# Altium schematic sheet 飞控.SchDoc
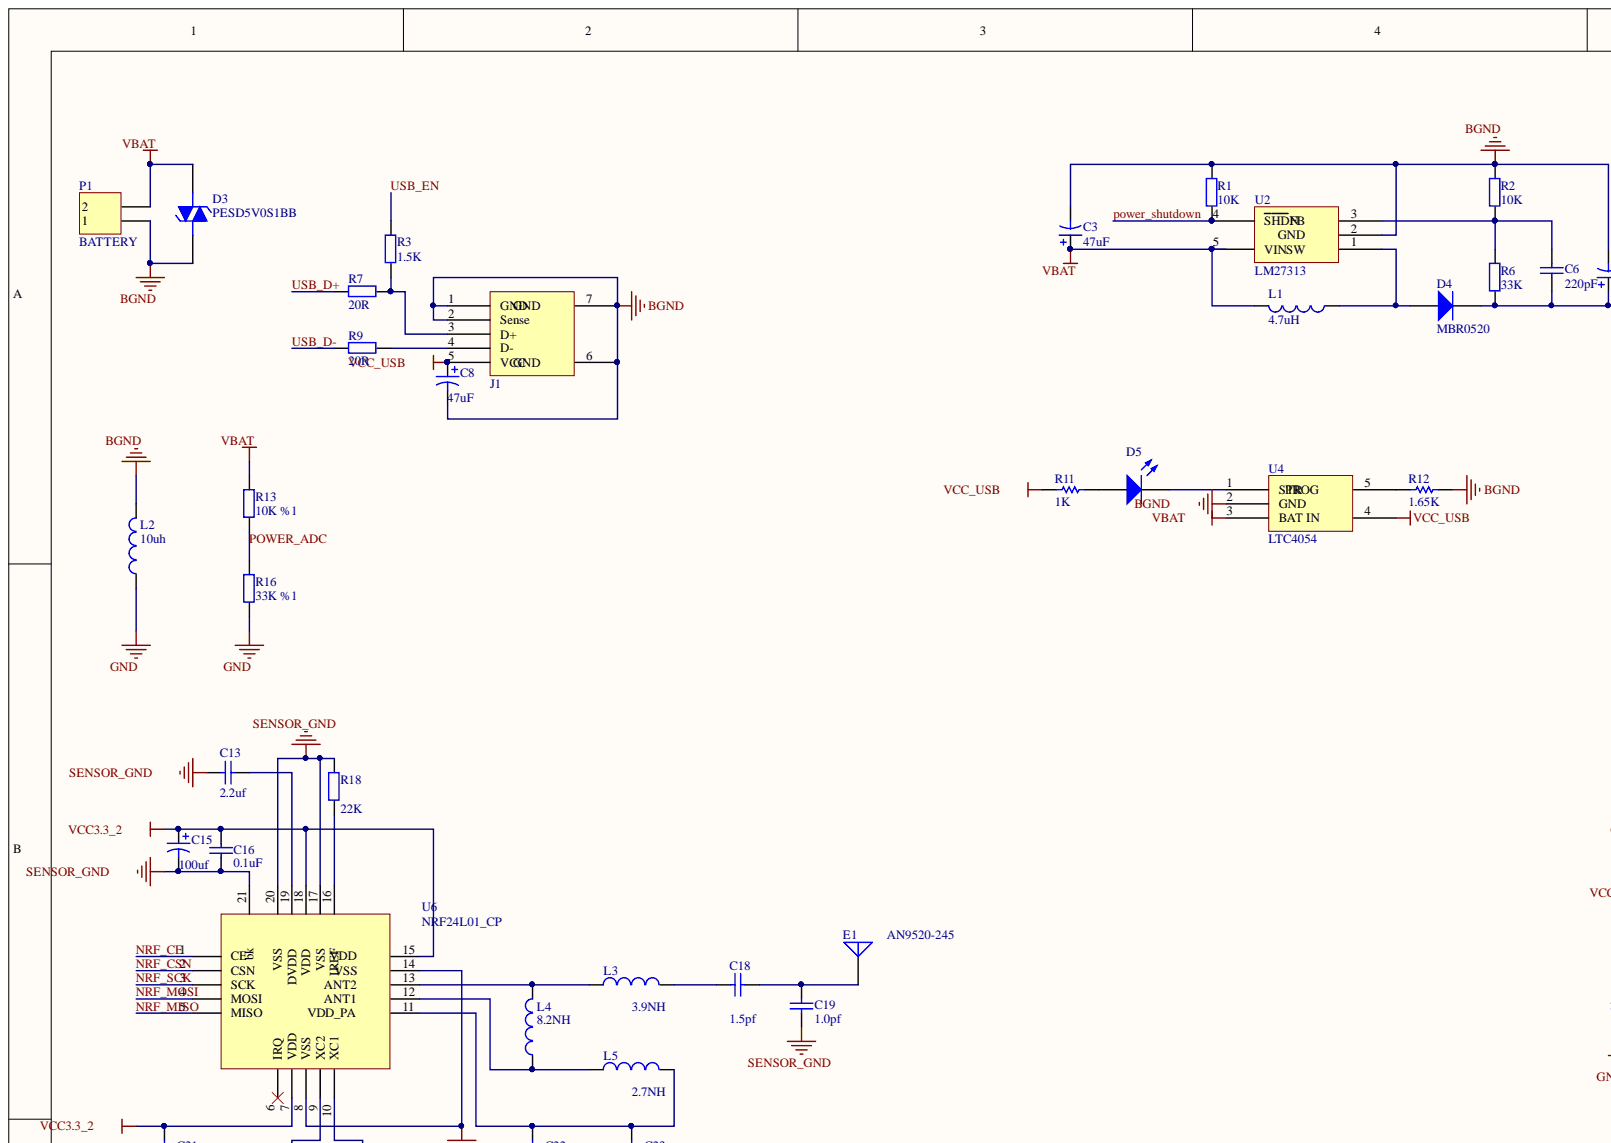
[diagram p0: 飞控.SchDoc, top left]
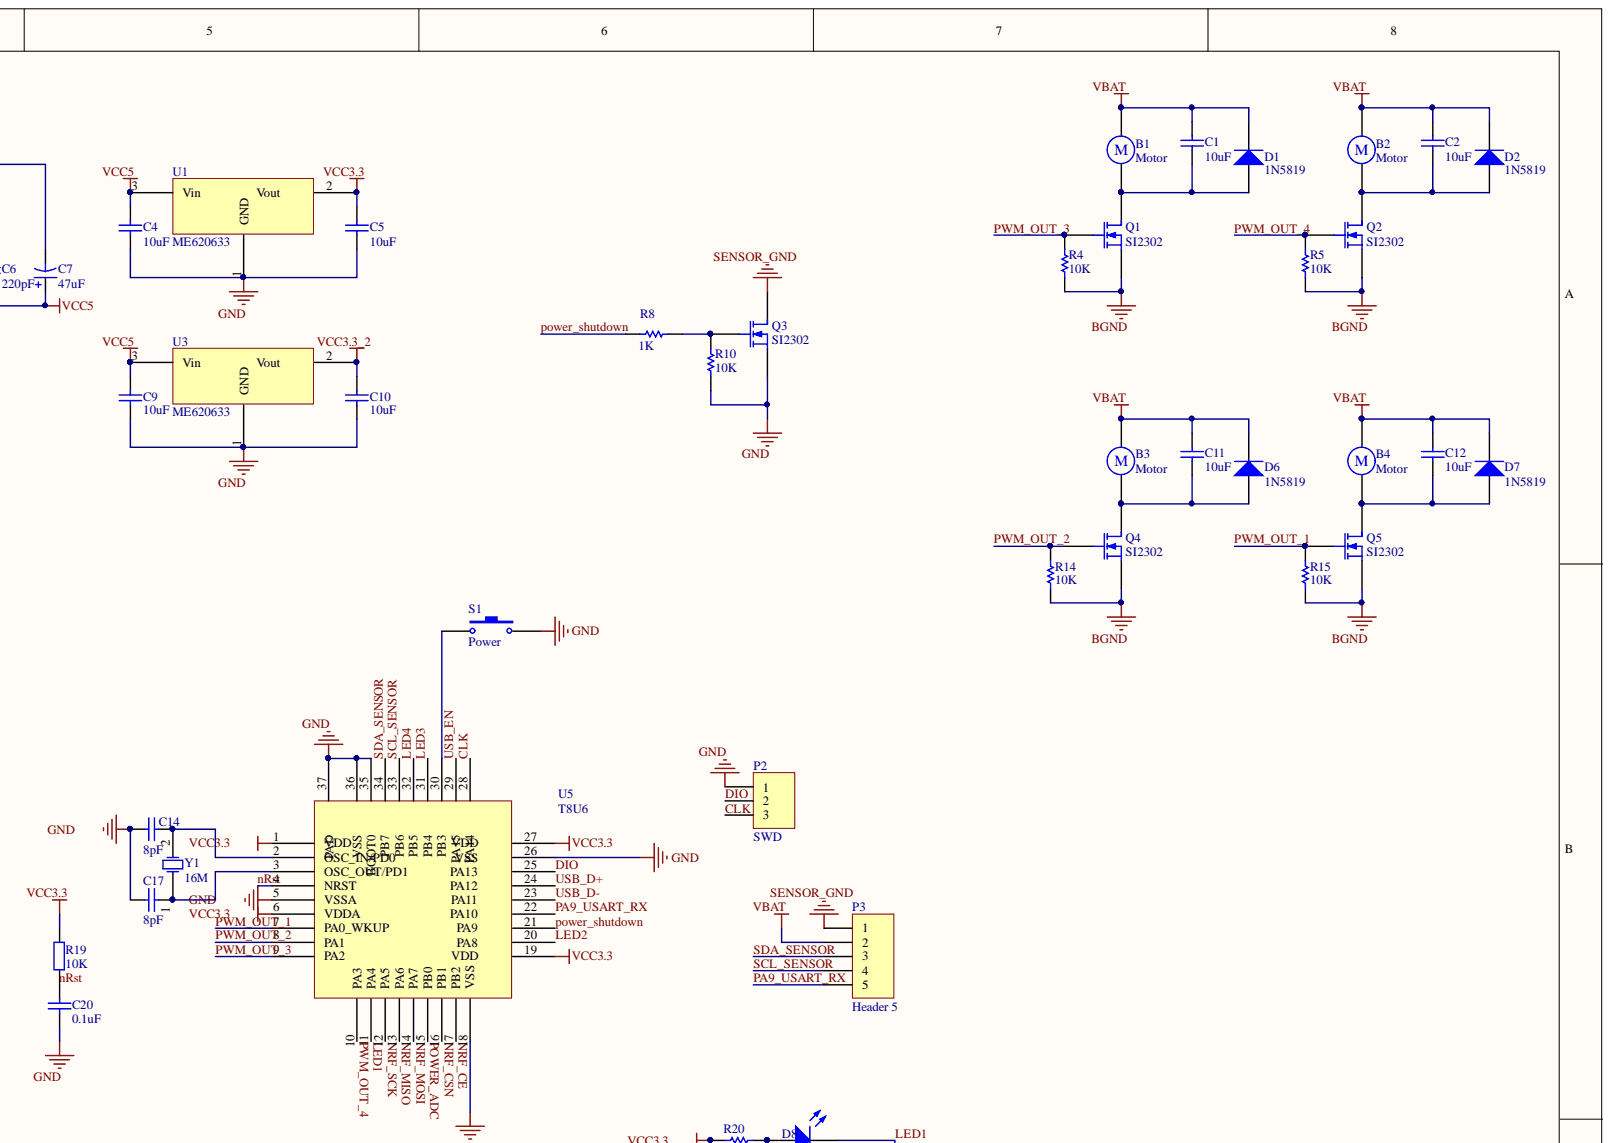
[diagram p1: 飞控.SchDoc, top right]
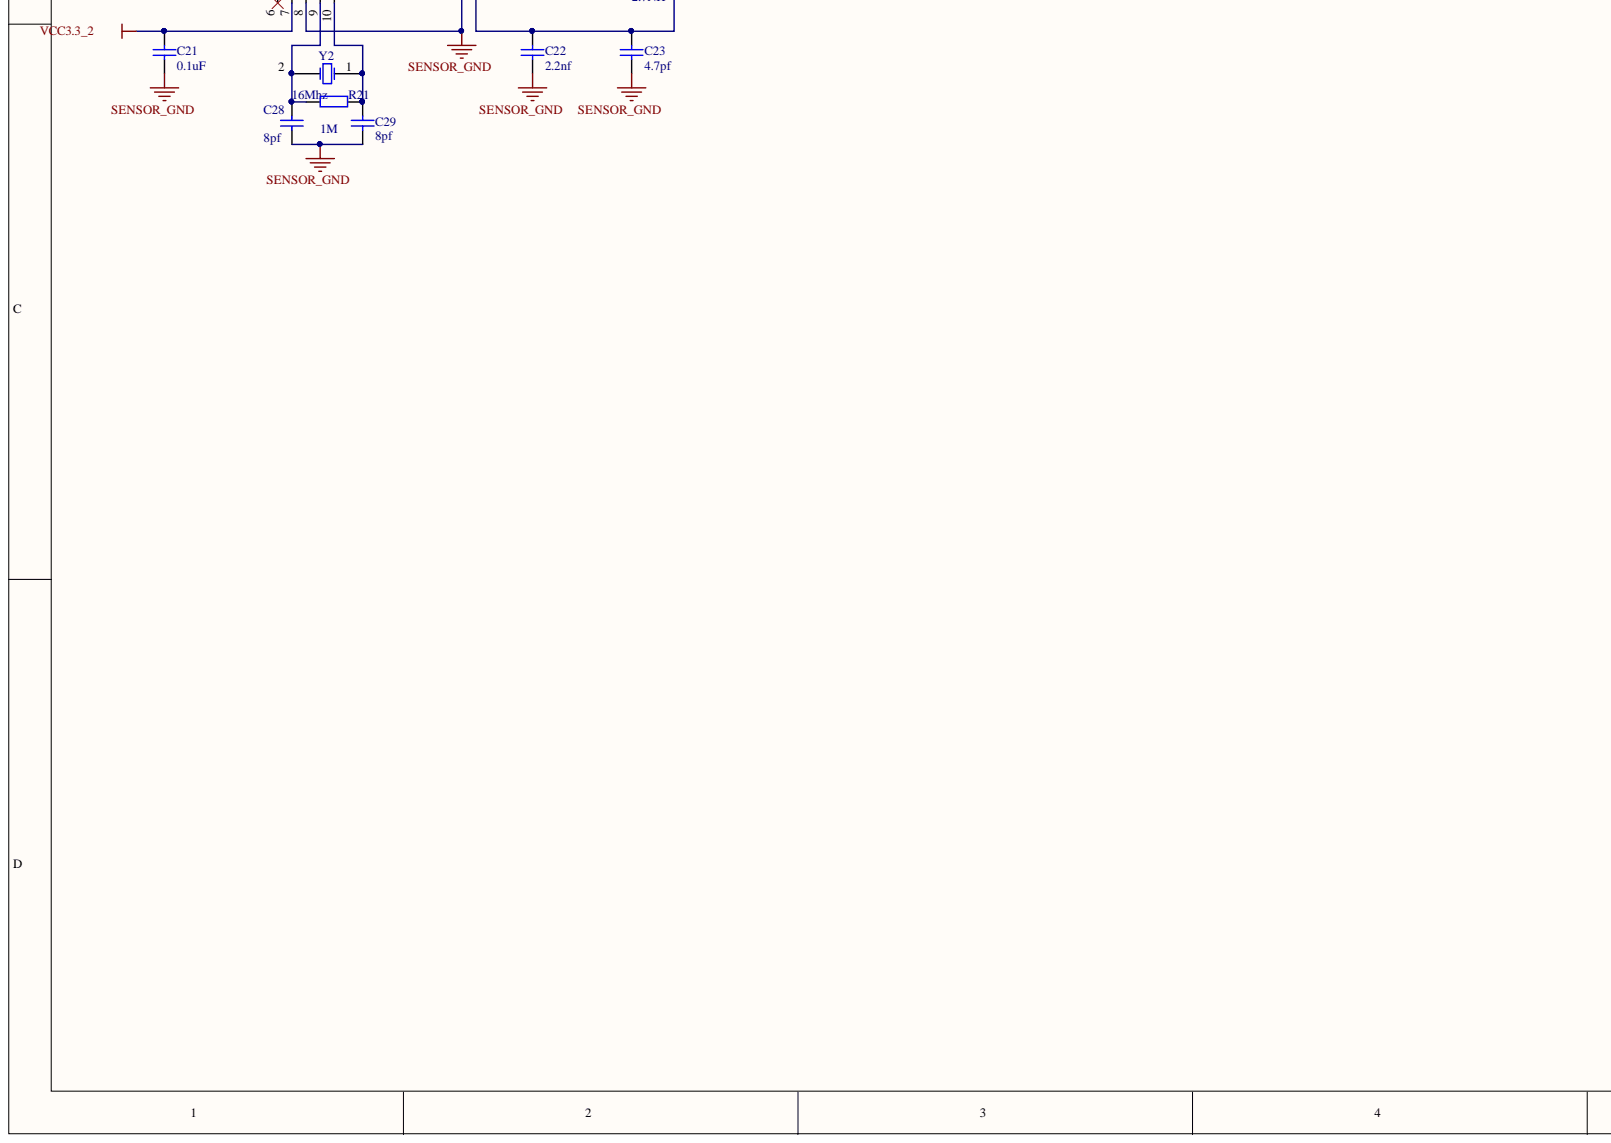
[diagram p2: 飞控.SchDoc, bottom left]
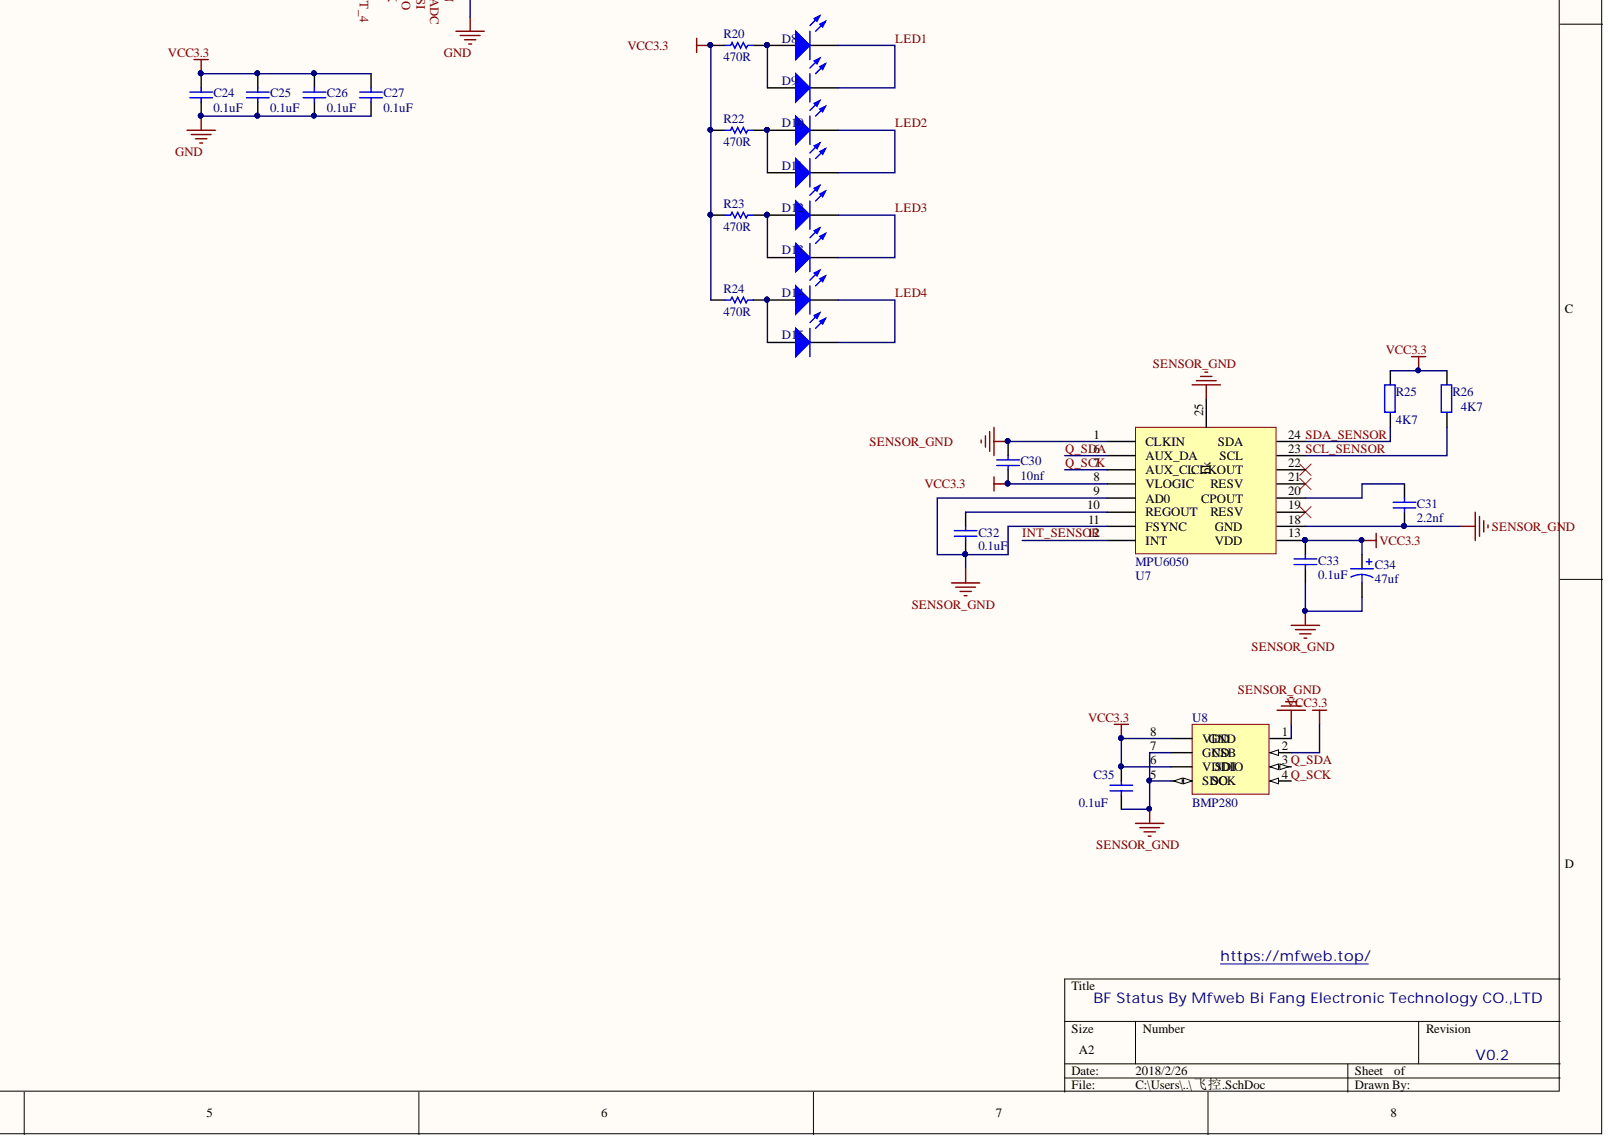
[diagram p3: 飞控.SchDoc, bottom right]

## Parts
B1  Motor  [2.54连接器]
B2  Motor  [2.54连接器]
B3  Motor  [2.54连接器]
B4  Motor  [2.54连接器]
C1  value 10uF  [0402]  (hidden comment: Cap)
C2  value 10uF  [0402]  (hidden comment: Cap)
C3  value 47uF  [0805]  (hidden comment: Cap Pol1)
C4  value 10uF  [0402]  (hidden comment: Cap)
C5  value 10uF  [0402]  (hidden comment: Cap)
C6  value 220pF  [0402]  (hidden comment: Cap)
C7  value 47uF  [0805D]  (hidden comment: Cap Pol1)
C8  value 47uF  [0805D]  (hidden comment: Cap Pol1)
C9  value 10uF  [0402]  (hidden comment: Cap)
C10  value 10uF  [0402]  (hidden comment: Cap)
C11  value 10uF  [0402]  (hidden comment: Cap)
C12  value 10uF  [0402]  (hidden comment: Cap)
C13  value 2.2uf  [0402]  (hidden comment: Cap)
C14  value 8pF  [0402]  (hidden comment: Cap)
C15  value 100uf  [0805D]  (hidden comment: Cap Pol1)
C16  value 0.1uF  [0402]  (hidden comment: Cap)
C17  value 8pF  [0402]  (hidden comment: Cap)
C18  value 1.5pf  [0402]  (hidden comment: Cap)
C19  value 1.0pf  [0402]  (hidden comment: Cap)
C20  value 0.1uF  [0402]  (hidden comment: Cap)
C21  value 0.1uF  [0402]  (hidden comment: Cap)
C22  value 2.2nf  [0402]  (hidden comment: Cap)
C23  value 4.7pf  [0402]  (hidden comment: Cap)
C24  value 0.1uF  [0402]  (hidden comment: Cap)
C25  value 0.1uF  [0402]  (hidden comment: Cap)
C26  value 0.1uF  [0402]  (hidden comment: Cap)
C27  value 0.1uF  [0402]  (hidden comment: Cap)
C28  value 8pf  [0402]  (hidden comment: Cap)
C29  value 8pf  [0402]  (hidden comment: Cap)
C30  value 10nf  [0402]  (hidden comment: Cap)
C31  value 2.2nf  [0402]  (hidden comment: Cap)
C32  value 0.1uF  [0402]  (hidden comment: Cap)
C33  value 0.1uF  [0402]  (hidden comment: Cap)
C34  value 47uf  [0603]  (hidden comment: Cap Pol1)
C35  value 0.1uF  [0402]  (hidden comment: Cap)
D1  1N5819  [0805P]
D2  1N5819  [0805P]
D3  PESD5V0S1BB  [0603]
D4  MBR0520  [SOD-123]
D5  LED0  [0805P]  (value not drawn)
D6  1N5819  [0805P]
D7  1N5819  [0805P]
D8  LED0  [0805P]  (value not drawn)
D9  LED0  [0805P]  (value not drawn)
D10  LED0  [0805P]  (value not drawn)
D11  LED0  [0805P]  (value not drawn)
D12  LED0  [0805P]  (value not drawn)
D13  LED0  [0805P]  (value not drawn)
D14  LED0  [0805P]  (value not drawn)
D15  LED0  [0805P]  (value not drawn)
E1  AN9520-245  [AN9520-245]
J1  MicroUsb  [Micro_USB]  (value not drawn)
L1  value 4.7uH  [CD32]  (hidden comment: Inductor)
L2  value 10uh  [CD32]  (hidden comment: Inductor)
L3  value 3.9NH  [0402]  (hidden comment: Inductor)
L4  value 8.2NH  [0402]  (hidden comment: Inductor)
L5  value 2.7NH  [0402]  (hidden comment: Inductor)
P1  BATTERY  [电池焊接头2P]
P2  SWD  [1.27J*3]
P3  Header 5  [HDR1X5]
Q1  SI2302  [SOT-23]
Q2  SI2302  [SOT-23]
Q3  SI2302  [SOT-23]
Q4  SI2302  [SOT-23]
Q5  SI2302  [SOT-23]
R1  value 10K  [0402]  (hidden comment: Res2)
R2  value 10K  [0402]  (hidden comment: Res2)
R3  value 1.5K  [0402]  (hidden comment: Res2)
R4  value 10K  [0402]  (hidden comment: Res1)
R5  value 10K  [0402]  (hidden comment: Res1)
R6  value 33K  [0402]  (hidden comment: Res2)
R7  value 20R  [0402]  (hidden comment: Res2)
R8  value 1K  [0402]  (hidden comment: Res1)
R9  value 20R  [0402]  (hidden comment: Res2)
R10  value 10K  [0402]  (hidden comment: Res1)
R11  value 1K  [0402]  (hidden comment: Res1)
R12  value 1.65K  [0402]  (hidden comment: Res1)
R13  value 10K %1  [0402]  (hidden comment: Res2)
R14  value 10K  [0402]  (hidden comment: Res1)
R15  value 10K  [0402]  (hidden comment: Res1)
R16  value 33K %1  [0402]  (hidden comment: Res2)
R18  value 22K  [0402]  (hidden comment: Res2)
R19  value 10K  [0402]  (hidden comment: Res2)
R20  value 470R  [0402]  (hidden comment: Res1)
R21  value 1M  [0402]  (hidden comment: Res2)
R22  value 470R  [0402]  (hidden comment: Res1)
R23  value 470R  [0402]  (hidden comment: Res1)
R24  value 470R  [0402]  (hidden comment: Res1)
R25  value 4K7  [0402]  (hidden comment: Res2)
R26  value 4K7  [0402]  (hidden comment: Res2)
S1  Power  [3*6_侧按键]
U1  ME620633  [SOT-23]
U2  LM27313  [SOT23]
U3  ME620633  [SOT-23]
U4  LTC4054  [SOT23]
U5  T8U6  [VFQFPN36]
U6  NRF24L01_CP  [QFN20]
U7  MPU6050  [QFN24_MPU]
U8  BMP280  [LGA-8]
Y1  16M  [3225四角有源晶振]
Y2  16Mhz  [3225四角有源晶振]

## Nets
BGND: C3.2 C7.2 C8.2 D3.2 J1.1(GND) J1.2(Sense) J1.6 J1.7 L2.2 P1.1 Q1.3 Q2.3 Q4.3 Q5.3 R1.1 R2.1 R4.1 R5.1 R12.2 R14.1 R15.1 U2.2 U4.2(GND)
GND: C4.2 C5.2 C9.2 C10.2 C14.1 C17.1 C20.1 C24.1 C25.1 C26.1 C27.1 L2.1 P2.1 Q3.3 R10.1 R16.1 S1.2 U1.1 U3.1 U5.5(VSSA) U5.18(VSS) U5.26 U5.35 U5.36 U5.37
SENSOR_GND: C13.2 C15.2 C16.1 C19.2 C21.1 C22.2 C23.2 C28.1 C29.1 C30.2 C31.1 C32.2 C33.1 C34.2 C35.1 P3.1 Q3.1 R18.2 U6.8(VSS) U6.14 U6.17 U6.20 U6.21 U7.1(CLKIN) U7.9(AD0) U7.11(FSYNC) U7.18 U7.25 U8.1 U8.5(SDO) U8.7(GND)
VBAT: B1.1 B2.1 B3.1 B4.1 C1.1 C2.1 C3.1 C11.1 C12.1 D1.2 D2.2 D3.1 D6.2 D7.2 L1.2 P1.2 P3.2 R13.2 U2.5 U4.3
VCC3.3: C5.1 C24.2 C25.2 C26.2 C27.2 C30.1 C33.2 C34.1 C35.2 R19.2 R20.1 R22.1 R23.1 R24.1 R25.2 R26.2 U1.2 U5.1(VDD) U5.6(VDDA) U5.19 U5.27 U7.8(VLOGIC) U7.13 U8.2 U8.6(VDDIO) U8.8(VDD)
VCC3.3_2: C10.1 C15.1 C16.2 C21.2 U3.2 U6.7(VDD) U6.15 U6.18
VCC5: C4.1 C6.1 C7.1 C9.1 D4.2 R6.2 U1.3 U3.3
VCC_USB: C8.1 J1.5(VCC) R11.1 U4.4
CLK: P2.3 U5.28
DIO: P2.2 U5.25
INT_SENSOR: U7.12(INT)
LED1: D8.2 D9.2 U5.11(PA4)
LED2: D10.2 D11.2 U5.20
LED3: D12.2 D13.2 U5.31
LED4: D14.2 D15.2 U5.32
NRF_CE: U5.17(PB2) U6.1(CE)
NRF_CSN: U5.16(PB1) U6.2(CSN)
NRF_MISO: U5.13(PA6) U6.5(MISO)
NRF_MOSI: U5.14(PA7) U6.4(MOSI)
NRF_SCK: U5.12(PA5) U6.3(SCK)
NRST: C20.2 R19.1 U5.4(NRST)
PA9_USART_RX: P3.5 U5.22
POWER_ADC: R13.1 R16.2 U5.15(PB0)
POWER_SHUTDOWN: R1.2 R8.2 U2.4(SHDN) U5.21
PWM_OUT_1: Q5.2 R15.2 U5.7(PA0_WKUP)
PWM_OUT_2: Q4.2 R14.2 U5.8(PA1)
PWM_OUT_3: Q1.2 R4.2 U5.9(PA2)
PWM_OUT_4: Q2.2 R5.2 U5.10(PA3)
Q_SCK: U7.7(AUX_CL) U8.4
Q_SDA: U7.6(AUX_DA) U8.3
SCL_SENSOR: P3.4 R26.1 U5.33 U7.23
SDA_SENSOR: P3.3 R25.1 U5.34 U7.24
USB_D+: R7.2 U5.24
USB_D-: R9.2 U5.23
USB_EN: R3.2 U5.29
NetB1_2: B1.2 C1.2 D1.1 Q1.1
NetB2_2: B2.2 C2.2 D2.1 Q2.1
NetB3_2: B3.2 C11.2 D6.1 Q4.1
NetB4_2: B4.2 C12.2 D7.1 Q5.1
NetC6_2: C6.2 R2.2 R6.1 U2.3
NetC13_1: C13.1 U6.19
NetC14_2: C14.2 U5.2(OSC_IN/PD0) Y1.2
NetC17_2: C17.2 U5.3(OSC_OUT/PD1) Y1.1
NetC18_1: C18.1 L3.2
NetC18_2: C18.2 C19.1 E1.1
NetC22_1: C22.1 C23.1 L5.2 U6.11
NetC28_2: C28.2 R21.1 U6.9(XC2) Y2.2
NetC29_2: C29.2 R21.2 U6.10(XC1) Y2.1
NetC31_2: C31.2 U7.20
NetC32_1: C32.1 U7.10(REGOUT)
NetD4_1: D4.1 L1.1 U2.1
NetD5_1: D5.1 R11.2
NetD5_2: D5.2 U4.1(STR)
NetD8_1: D8.1 D9.1 R20.2
NetD10_1: D10.1 D11.1 R22.2
NetD12_1: D12.1 D13.1 R23.2
NetD14_1: D14.1 D15.1 R24.2
NetJ1_3: J1.3(D+) R3.1 R7.1
NetJ1_4: J1.4(D-) R9.1
NetL3_1: L3.1 L4.2 U6.13
NetL4_1: L4.1 L5.1 U6.12
NetQ3_2: Q3.2 R8.1 R10.2
NetR12_1: R12.1 U4.5
NetR18_1: R18.1 U6.16
NetS1_1: S1.1 U5.30

Not connected: U6 6(IRQ); U7 19 21 22

## Library data of the parts
B1-B4 = Motor: Motor, General Kind; Component Kind: Standard; LatestRevisionDate: 17-Jul-2002; LatestRevisionNote: Re-released for DXP Platform.; Library Name: Miscellaneous Devices.IntLib; PackageReference: RB5-10.5; Published: 24-Mar-1999; Publisher: Altium Limited
C1 C2 C4-C6 C9-C14 C16-C33 C35 = Cap: Capacitor; Component Kind: Standard; LatestRevisionDate: 17-Jul-2002; LatestRevisionNote: Re-released for DXP Platform.; Library Name: Miscellaneous Devices.IntLib; PackageReference: RAD-0.3; Published: 8-Jun-2000; Signal Integrity: Cap; Simulation: CAP; Publisher: Altium Limited
  C14 C16 C17 C24-C27: PackageDescription: Radial Cap, Thru-Hole; 2 Leads; 0.3 in Pin Spacing
C3 C7 C8 C15 C34 = Cap Pol1: Polarized Capacitor (Radial); Component Kind: Standard; LatestRevisionDate: 17-Jul-2002; LatestRevisionNote: Re-released for DXP Platform.; Library Name: Miscellaneous Devices.IntLib; PackageReference: RB7.6-15; Published: 8-Jun-2000; Signal Integrity: Cap Pol; Simulation: CAP; Publisher: Altium Limited
D1 D2 D4 D6 D7 = Diode: Default Diode; Code_JEDEC: DO-214-AB; Component Kind: Standard; LatestRevisionDate: 17-Jul-2002; LatestRevisionNote: Re-released for DXP Platform.; Library Name: Miscellaneous Devices.IntLib; PackageDescription: DO-214-AB/SMC; 2 C-Bend Leads; Body 7.9 x 5.9 mm, inc. leads (LxW); PackageReference: SMC; PackageVersion: Sep-1996; Published: 8-Jun-2000; Signal Integrity: Diode; Simulation: DIODE; Publisher: Altium Limited
D3 = TSS: Component Kind: Standard; Library Name: T8U6_SLIB.SchLib
D5 D8-D15 = LED0: Typical INFRARED GaAs LED; Component Kind: Standard; LatestRevisionDate: 08-Jul-2005; LatestRevisionNote: Mech Layer 1 removed.; Library Name: Miscellaneous Devices.IntLib; PackageReference: LED-0; Published: 8-Jun-2000; Simulation: LED0; Publisher: Altium Limited
E1 = Antenna: Generic Antenna; Component Kind: Standard; LatestRevisionDate: 17-Jul-2002; LatestRevisionNote: Re-released for DXP Platform.; Library Name: Miscellaneous Devices.IntLib; PackageReference: PIN1; Published: 24-Mar-1999; Publisher: Altium Limited
L1-L5 = Inductor: Code_IEC: 1005; Code_JEITA: 0402; Component Kind: Standard; Description: Inductor; LatestRevisionDate: 17-Jul-2002; LatestRevisionNote: Re-released for DXP Platform.; Library Name: Miscellaneous Devices.IntLib; PackageReference: 0402-A; Published: 8-Jun-2000; Signal Integrity: Inductor; Simulation: INDUCTOR; Publisher: Altium Limited
P1 = Header 2: Header, 2-Pin; Component Kind: Standard; LatestRevisionDate: 17-Jul-2002; LatestRevisionNote: Re-released for DXP Platform.; Library Name: Miscellaneous Connectors.IntLib; Signal Integrity: Connector; Publisher: Altium Limited
P2 = Header 3: Header, 3-Pin; Component Kind: Standard; LatestRevisionDate: 17-Jul-2002; LatestRevisionNote: Re-released for DXP Platform.; Library Name: Miscellaneous Connectors.IntLib; Signal Integrity: Connector; Publisher: Altium Limited
P3 = Header 5: Header, 5-Pin; Component Kind: Standard; LatestRevisionDate: 17-Jul-2002; LatestRevisionNote: Re-released for DXP Platform.; Signal Integrity: Connector; Library Name: x-quadrotor-mini.IntLib; Publisher: Altium Limited
Q1-Q5 = MOSFET-N: N-Channel MOSFET; Component Kind: Standard; LatestRevisionDate: 17-Jul-2002; LatestRevisionNote: Re-released for DXP Platform.; Library Name: Miscellaneous Devices.IntLib; PackageReference: E3; PackageVersion: 1995/1996; Published: 8-Jun-2000; Simulation: NMOS; Publisher: Altium Limited
R1-R3 R6 R7 R9 R13 R16 R18 R19 R25 R26 = Res2: Resistor; Component Kind: Standard; LatestRevisionDate: 17-Jul-2002; LatestRevisionNote: Re-released for DXP Platform.; Library Name: Miscellaneous Devices.IntLib; PackageReference: AXIAL-0.4; Published: 8-Jun-2000; Signal Integrity: Res; Simulation: RESISTOR; Publisher: Altium Limited
R4 R5 R8 R10-R12 R14 R15 R20 R22-R24 = Res1: Resistor; Component Kind: Standard; LatestRevisionDate: 17-Jul-2002; LatestRevisionNote: Re-released for DXP Platform.; Library Name: Miscellaneous Devices.IntLib; PackageReference: AXIAL-0.3; Published: 8-Jun-2000; Signal Integrity: Res; Simulation: RESISTOR; Publisher: Altium Limited
R21 = Res2: Resistor; Value: 1M; Component Kind: Standard; LatestRevisionDate: 17-Jul-2002; LatestRevisionNote: Re-released for DXP Platform.; Library Name: Miscellaneous Devices.IntLib; PackageReference: AXIAL-0.4; Published: 8-Jun-2000; Signal Integrity: Res; Simulation: RESISTOR; Publisher: Altium Limited
S1 = SW-PB: Switch; Component Kind: Standard; LatestRevisionDate: 17-Jul-2002; LatestRevisionNote: Re-released for DXP Platform.; Library Name: Miscellaneous Devices.IntLib; PackageDescription: Switch; 2 Leads; PackageReference: SPST-2; Published: 24-Mar-1999; Publisher: Altium Limited
Y1 Y2 = XTAL: Crystal Oscillator; Component Kind: Standard; LatestRevisionDate: 17-Jul-2002; LatestRevisionNote: Re-released for DXP Platform.; Library Name: Miscellaneous Devices.IntLib; PackageReference: R38; Published: 8-Jun-2000; Simulation: XTAL; Publisher: Altium Limited
  Y1: PackageDescription: Crystal, Thru-Hole; 2 Leads; Body 3.1 x 8.2 mm (Dia.xH)
J1 = MicroUsb: Component Kind: Standard; Library Name: Lib.SchLib
U1 U3 = ME620633: Component Kind: Standard; Library Name: Schlib1.SchLib
U2 = LM27313: Component Kind: Standard; Library Name: T8U6_SLIB.SchLib
U4 = LTC4054: Component Kind: Standard; Library Name: T8U6_SLIB.SchLib
U5 = T8U6: Component Kind: Standard; Library Name: T8U6_SLIB.SchLib
U6 = NRF24L01_CP: Component Kind: Standard; Library Name: 库.SchLib
U7 = MPU6050: Component Kind: Standard; Library Name: 库.SchLib
U8 = BMP280: Component Kind: Standard; Library Name: MiniFly .IntLib
